# Altium schematic sheet wls2-motor-a4988.SchDoc(wls2-motor-a4988)
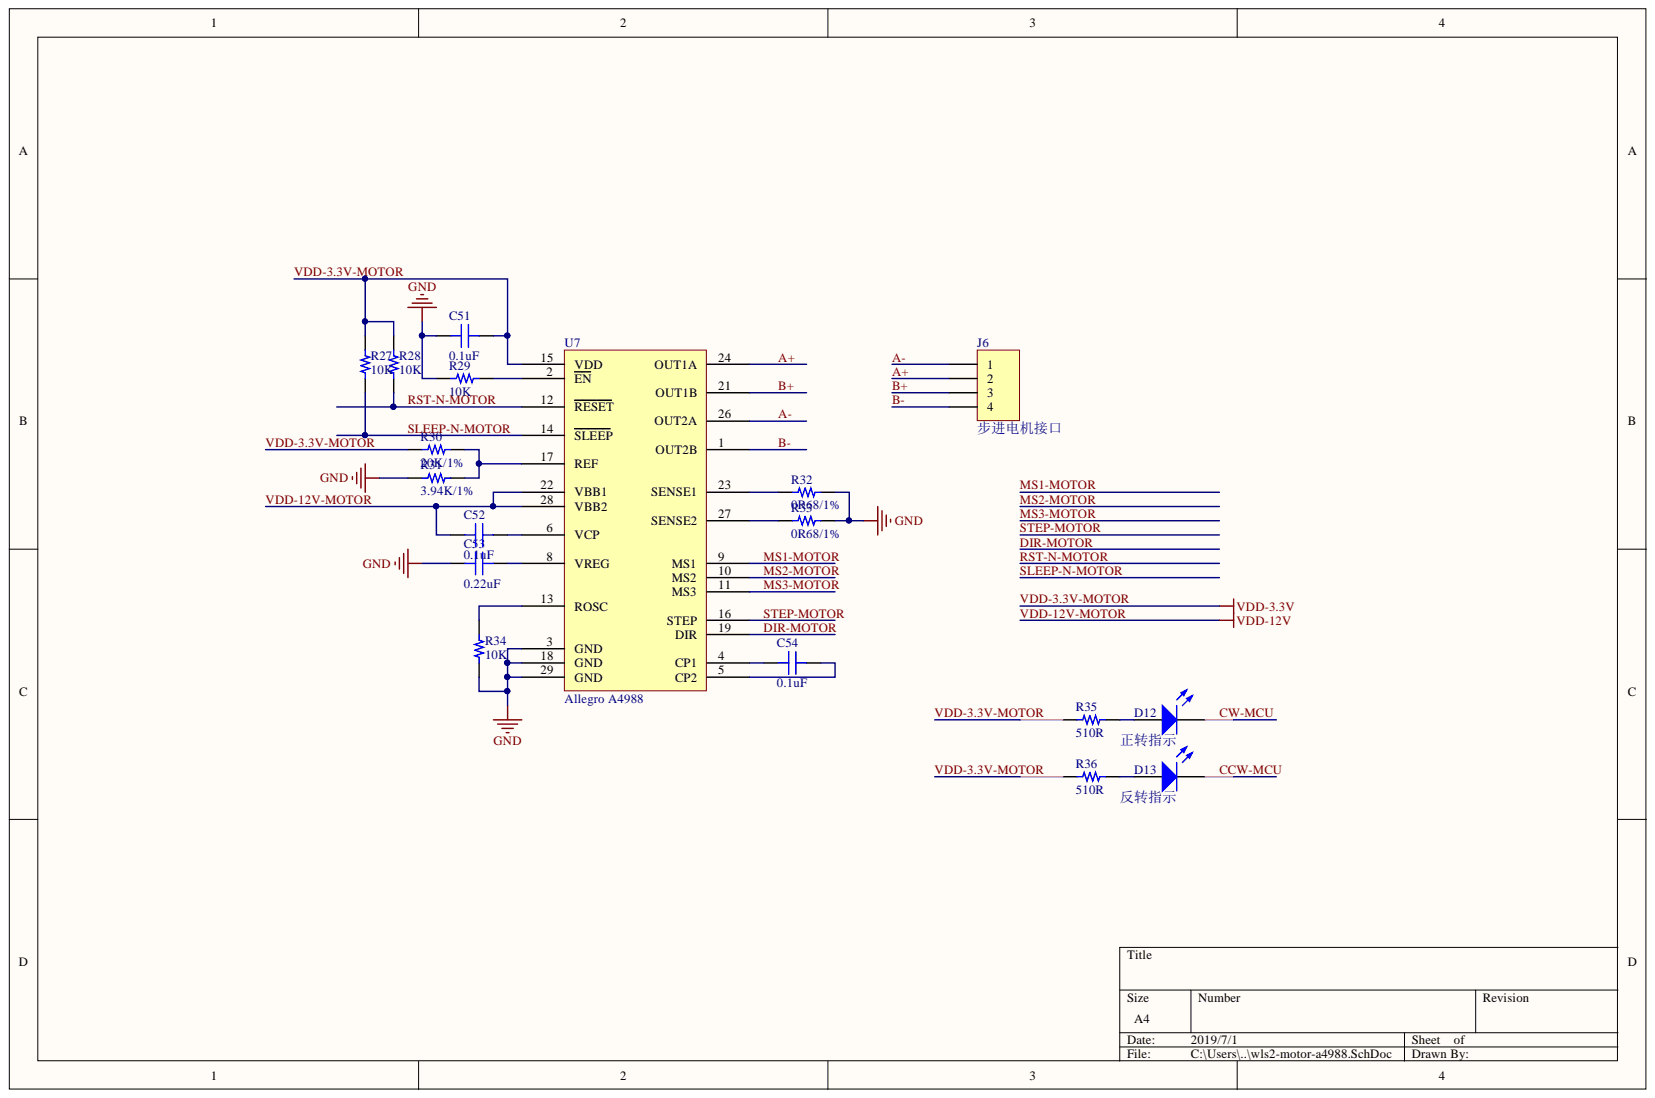
[diagram p0: wls2-motor-a4988.SchDoc]

## Parts
C51  0.1uF  [RES 0402/1005]
C52  0.1uF  [RES 0402/1005]
C53  0.22uF  [RES 0402/1005]
C54  0.1uF  [RES 0402/1005]
D12  正转指示  [LED 0805/2012 GREEN]
D13  反转指示  [LED 0805/2012 GREEN]
J6  步进电机接口  [PH2.0-4PIN_JST]
R27  10K  [RES 0402/1005]
R28  10K  [RES 0402/1005]
R29  10K  [RES 0402/1005]
R30  20K/1%  [RES 0402/1005]
R31  3.94K/1%  [RES 0402/1005]
R32  0R68/1%  [RES 0402/1005]
R33  0R68/1%  [RES 0402/1005]
R34  10K  [RES 0402/1005]
R35  510R  [RES 0402/1005]
R36  510R  [RES 0402/1005]
U7  Allegro A4988  [QFN50P500X500X90_HS-29N]

## Nets
GND: C51.1 C53.1 R29.2 R31.1 R32.2 R33.2 R34.1 U7.3(GND) U7.18(GND) U7.29(GND)
A+: J6.2 U7.24(OUT1A)
A-: J6.1 U7.26(OUT2A)
B+: J6.3 U7.21(OUT1B)
B-: J6.4 U7.1(OUT2B)
CCW-MCU: D13.2
CW-MCU: D12.2
DIR-MOTOR: U7.19(DIR)
MS1-MOTOR: U7.9(MS1)
MS2-MOTOR: U7.10(MS2)
MS3-MOTOR: U7.11(MS3)
RST-N-MOTOR: R28.1 U7.12(RESET)
SLEEP-N-MOTOR: R27.1 U7.14(SLEEP)
STEP-MOTOR: U7.16(STEP)
VDD-3.3V-MOTOR: C51.2 R27.2 R28.2 R30.2 R35.1 R36.1 U7.15(VDD)
VDD-12V-MOTOR: C52.1 U7.22(VBB1) U7.28(VBB2)
NetC52_2: C52.2 U7.6(VCP)
NetC53_2: C53.2 U7.8(VREG)
NetC54_1: C54.1 U7.5(CP2)
NetC54_2: C54.2 U7.4(CP1)
NetD12_1: D12.1 R35.2
NetD13_1: D13.1 R36.2
NetR29_1: R29.1 U7.2(EN)
NetR30_1: R30.1 R31.2 U7.17(REF)
NetR32_1: R32.1 U7.23(SENSE1)
NetR33_1: R33.1 U7.27(SENSE2)
NetR34_2: R34.2 U7.13(ROSC)

## Library data of the parts
C51-C54 = Capacitor: SMT Capacitor; Component Kind: Standard; Library Name: Passives.SchLib
  C51 C52 C54: Value: 0.1uF
  C53: Value: 0.22uF
D12 D13 = LED0: 贴片指示灯; Component Kind: Standard; LatestRevisionDate: 08-Jul-2005; LatestRevisionNote: Mech Layer 1 removed.; Library Name: Miscellaneous Devices.IntLib; PackageReference: LED-0; Published: 8-Jun-2000; Simulation: LED0; Publisher: Altium Limited
J6 = Header 4: Header, 4-Pin; Component Kind: Standard; LatestRevisionDate: 17-Jul-2002; LatestRevisionNote: Re-released for DXP Platform.; Library Name: Miscellaneous Connectors.IntLib; Signal Integrity: Connector; Publisher: Altium Limited
R27-R36 = Resistor: SMT Resistor; Component Kind: Standard; Library Name: Passives.SchLib
  R27-R29 R34: Value: 10K
  R30: Value: 20K/1%
  R31: Value: 3.94K/1%
  R32 R33: Value: 0R68/1%
  R35 R36: Value: 510R
U7 = Allegro A4988: Component Kind: Standard; Library Name: Motor Driver.SchLib
